# Altium schematic sheet [019.00256]Expansion Shield for Driver(TB6612)(V1.0).SchDoc
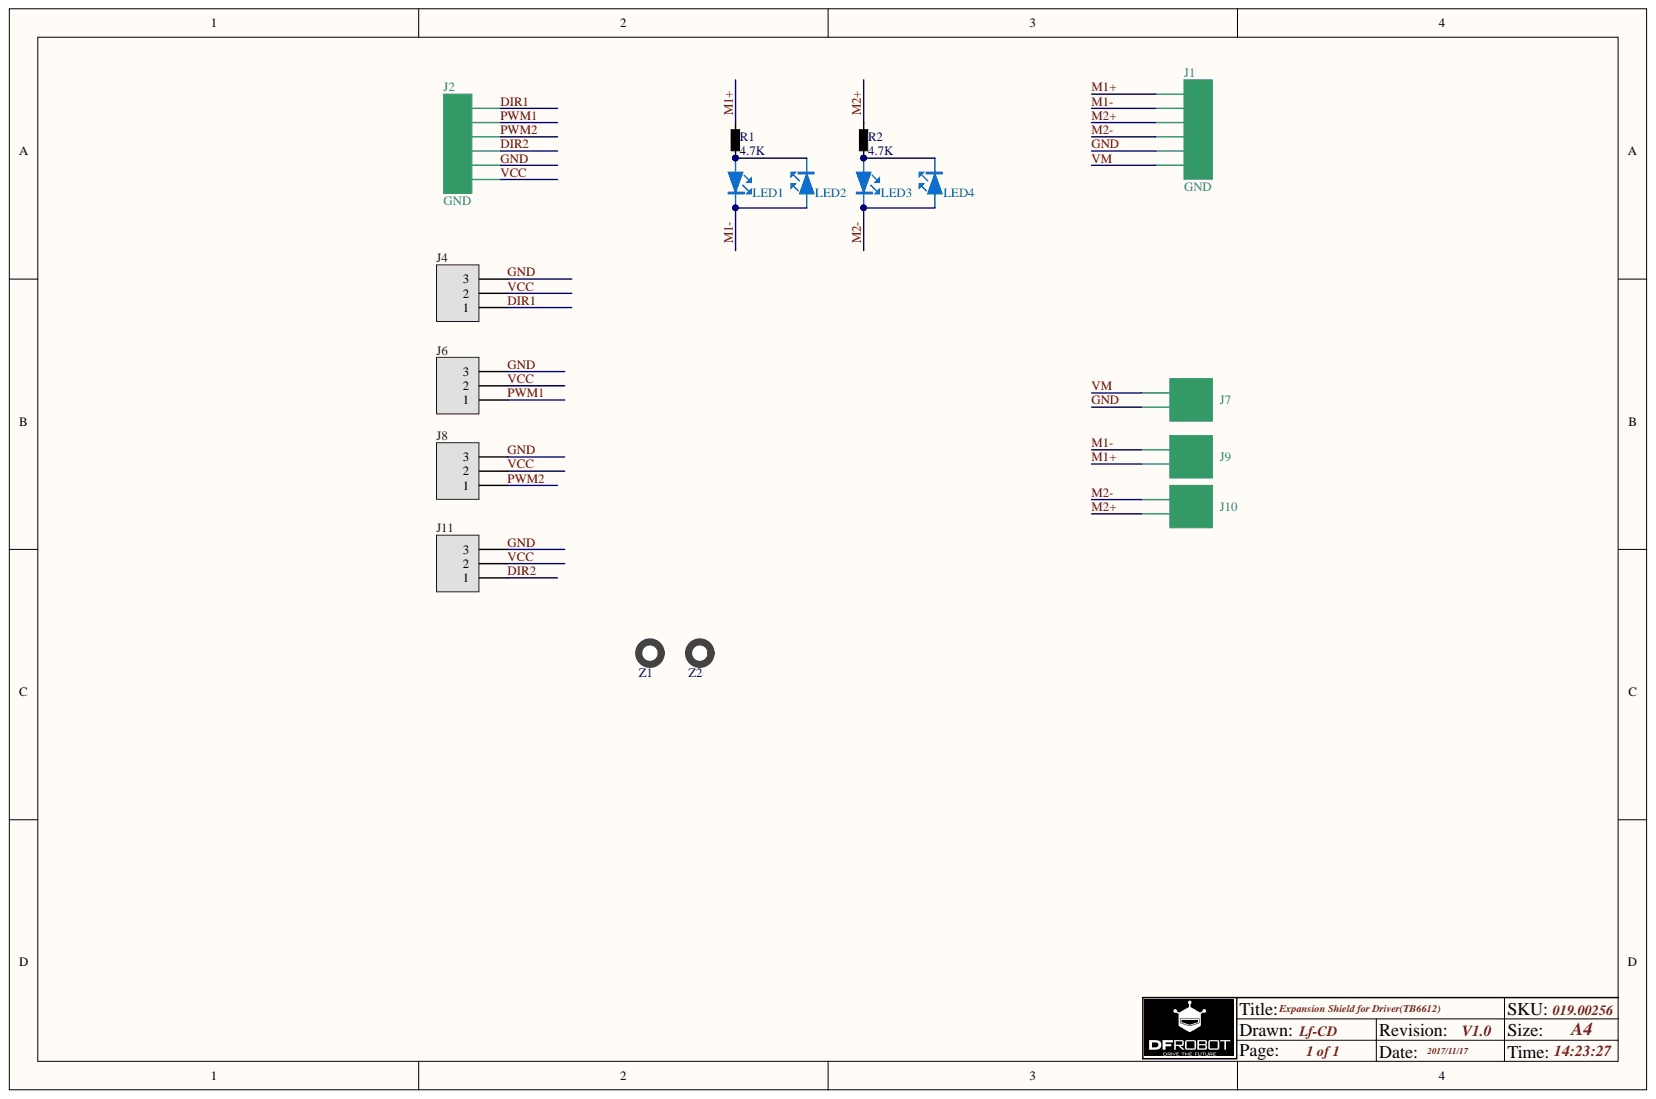
[diagram p0: [019.00256]Expansion Shield for Driver(TB6612)(V1.0).SchDoc]

## Parts
J1  value GND  [排母_2.54_D6_绿]  (hidden comment: 2.54绿色单排排母-6P)
J2  value GND  [排母_2.54_D6_绿]  (hidden comment: 2.54绿色单排排母-6P)
J4  PH2.0-3P立式贴片座  [PH2.0_3P_UP]  (value not drawn)
J6  PH2.0-3P立式贴片座  [PH2.0_3P_UP]  (value not drawn)
J7  接线柱KF127-5.0-2P  [KF127_5.08-2P]  (value not drawn)
J8  PH2.0-3P立式贴片座  [PH2.0_3P_UP]  (value not drawn)
J9  接线柱KF127-5.0-2P  [KF127_5.08-2P]  (value not drawn)
J10  接线柱KF127-5.0-2P  [KF127_5.08-2P]  (value not drawn)
J11  PH2.0-3P立式贴片座  [PH2.0_3P_UP]  (value not drawn)
LED1  贴片LED蓝色0603  [LED_0603_B]  (value not drawn)
LED2  贴片LED蓝色0603  [LED_0603_B]  (value not drawn)
LED3  贴片LED蓝色0603  [LED_0603_B]  (value not drawn)
LED4  贴片LED蓝色0603  [LED_0603_B]  (value not drawn)
R1  value 4.7K  [Res_0603]  (hidden comment: 贴片电阻0603-4.7K-5%)
R2  value 4.7K  [Res_0603]  (hidden comment: 贴片电阻0603-4.7K-5%)
Z1  HOLE  [安装孔B]  (value not drawn)  (no BOM)
Z2  HOLE  [安装孔B]  (value not drawn)  (no BOM)

## Nets
DIR1: J2.1 J4.1
DIR2: J2.4 J11.1
GND: J1.5 J2.5 J4.3 J6.3 J7.1 J8.3 J11.3
M1+: J1.1 J9.1 R1.2
M1-: J1.2 J9.2 LED1.2 LED2.1
M2+: J1.3 J10.1 R2.2
M2-: J1.4 J10.2 LED3.2 LED4.1
PWM1: J2.2 J6.1
PWM2: J2.3 J8.1
VCC: J2.6 J4.2 J6.2 J8.2 J11.2
VM: J1.6 J7.2
NetLED1_1: LED1.1 LED2.2 R1.1
NetLED3_1: LED3.1 LED4.2 R2.1

Not connected: Z1 1; Z2 1

## Library data of the parts
J1 J2 = CON6: Connector; Order: 8; Component Kind: Standard
J4 J6 J8 J11 = Header 3: Header, 3-Pin; Order: 5; Component Kind: Standard; Library Name: Miscellaneous Connectors.IntLib; Signal Integrity: Connector; Value: PH2.0-3P
J7 J9 J10 = Header 2: Header, 2-Pin; Order: 8; Value: KF350; Component Kind: Standard; Library Name: Miscellaneous Connectors.IntLib
LED1-LED4 = LED: Order: 4; Value: ON; Component Kind: Standard; Library Name: 原理图封装库.SchLib
R1 R2 = Res: Order: 1; Component Kind: Standard; Library Name: 原理图封装库.SchLib
Z1 Z2 = HOLE: Library Name: 原理图封装库.SchLib
